# Altium schematic sheet ADC - ADC.SchDoc
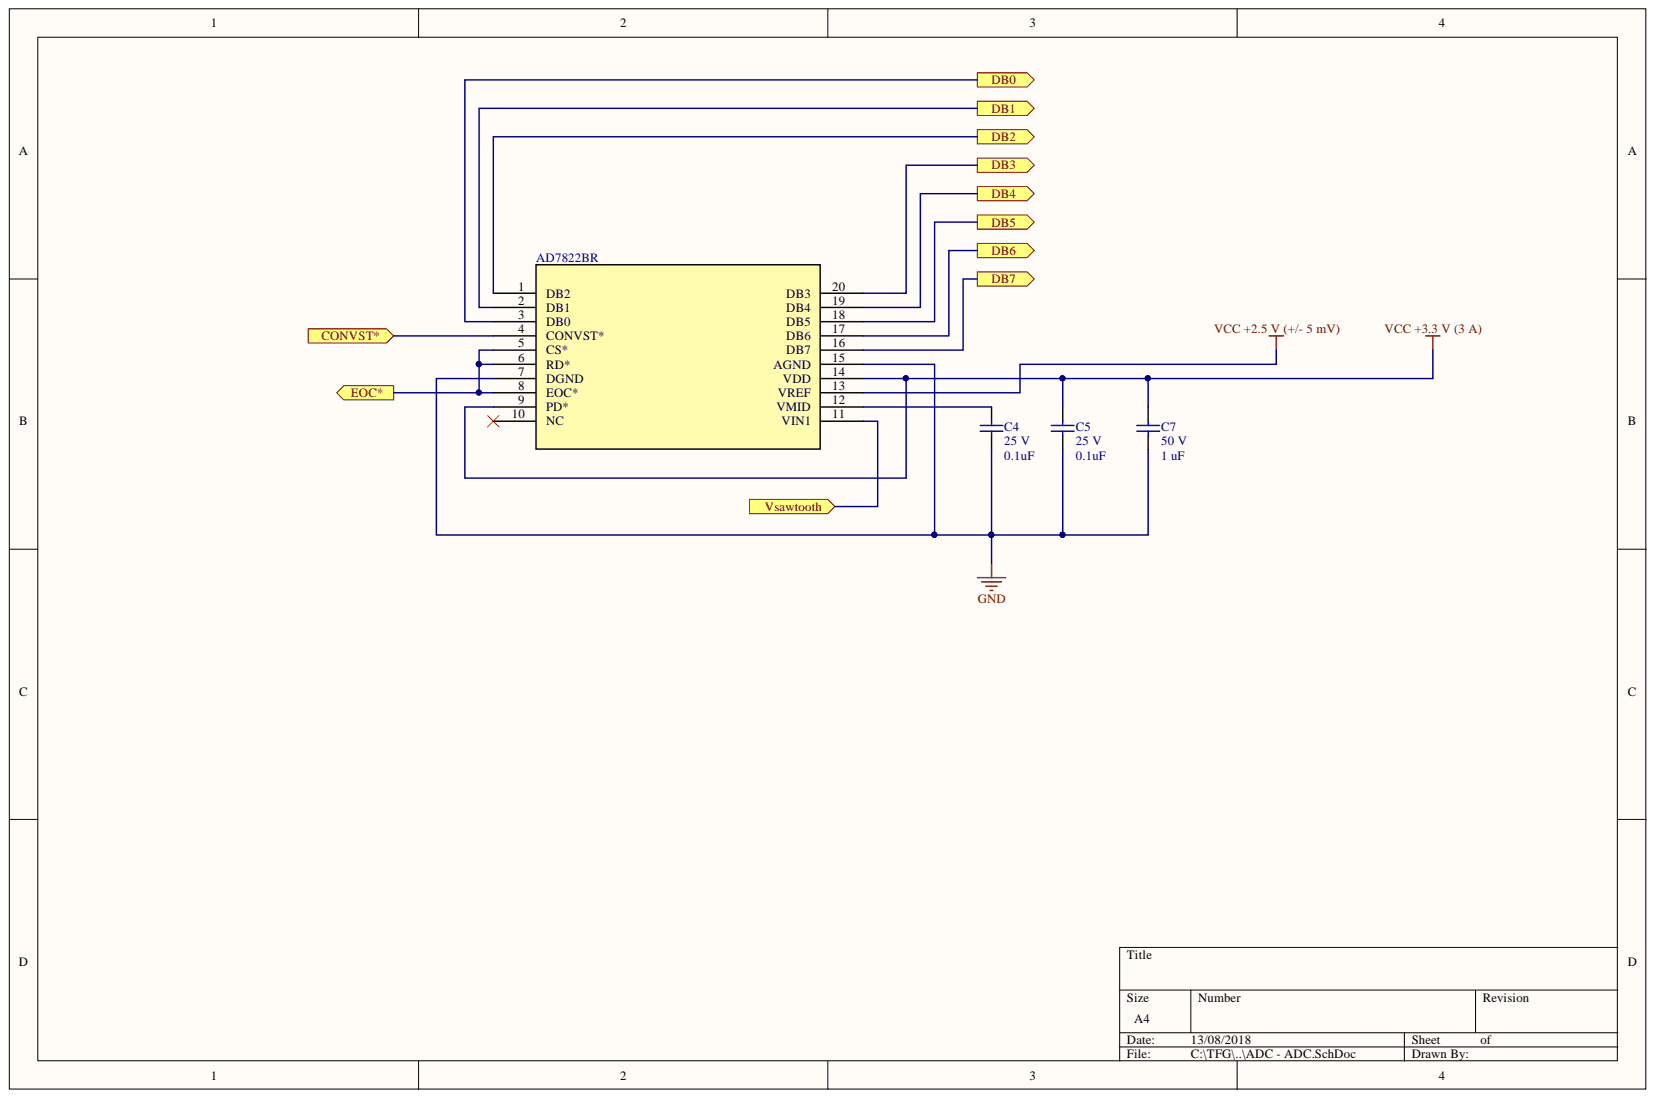
[diagram p0: ADC - ADC.SchDoc]

## Parts
AD7822BR  AD7822BR  [RW_20-M (AD7822BR)]
C4  25 V  value 0.1uF  [CAP_0201A-M [MM:0603]]
C5  25 V  value 0.1uF  [CAP_0201A-M [MM:0603]]
C7  50 V  value 1 uF  [CAP_0805J-M [MM:2012]/":0805]

## Ports
CONVST*, DB0, DB1, DB2, DB3, DB4, DB5, DB6, DB7, EOC*, Vsawtooth

## Nets
GND: AD7822BR.7(DGND) AD7822BR.15(AGND) C4.1 C5.1 C7.1
VCC +2.5 V (+/- 5 mV): AD7822BR.13(VREF)
VCC +3.3 V (3 A): AD7822BR.9(PD*) AD7822BR.14(VDD) C5.2 C7.2
CONVST*: AD7822BR.4(CONVST*) ; port CONVST*
DB0: AD7822BR.3(DB0) ; port DB0
DB1: AD7822BR.2(DB1) ; port DB1
DB2: AD7822BR.1(DB2) ; port DB2
DB3: AD7822BR.20(DB3) ; port DB3
DB4: AD7822BR.19(DB4) ; port DB4
DB5: AD7822BR.18(DB5) ; port DB5
DB6: AD7822BR.17(DB6) ; port DB6
DB7: AD7822BR.16(DB7) ; port DB7
EOC*: AD7822BR.5(CS*) AD7822BR.6(RD*) AD7822BR.8(EOC*) ; port EOC*
Vsawtooth: AD7822BR.11(VIN1) ; port Vsawtooth
NetAD7822BR_12: AD7822BR.12(VMID) C4.2

Not connected: AD7822BR 10(NC)

## Library data of the parts
AD7822BR = AD7822BR: ADC; Built_By: EMA_UL_Team; C: Copyright (C) 2015 Accelerated Designs. All rights reserved; Vendor: Analog Devices Inc; Component Kind: Standard; Library Name: ADC Library.SchLib; Manufacturer_Part_Number: AD7822BR; RefDes: RefDes; Type: DEV; Value: Value
C4 C5 C7 = Cap Semi: Capacitor (Semiconductor SIM Model); Component Kind: Standard; LatestRevisionDate: 29-May-2009; LatestRevisionNote: IPC-7351 Footprint Added.; Library Name: Miscellaneous Devices.IntLib; PackageDescription: Chip Capacitor; PackageReference: C1206; Published: 8-Jun-2000; Signal Integrity: Cap Semi; Simulation: CAP; Publisher: Altium Limited
